# Altium schematic sheet AMX30.SchDoc(AMX30)
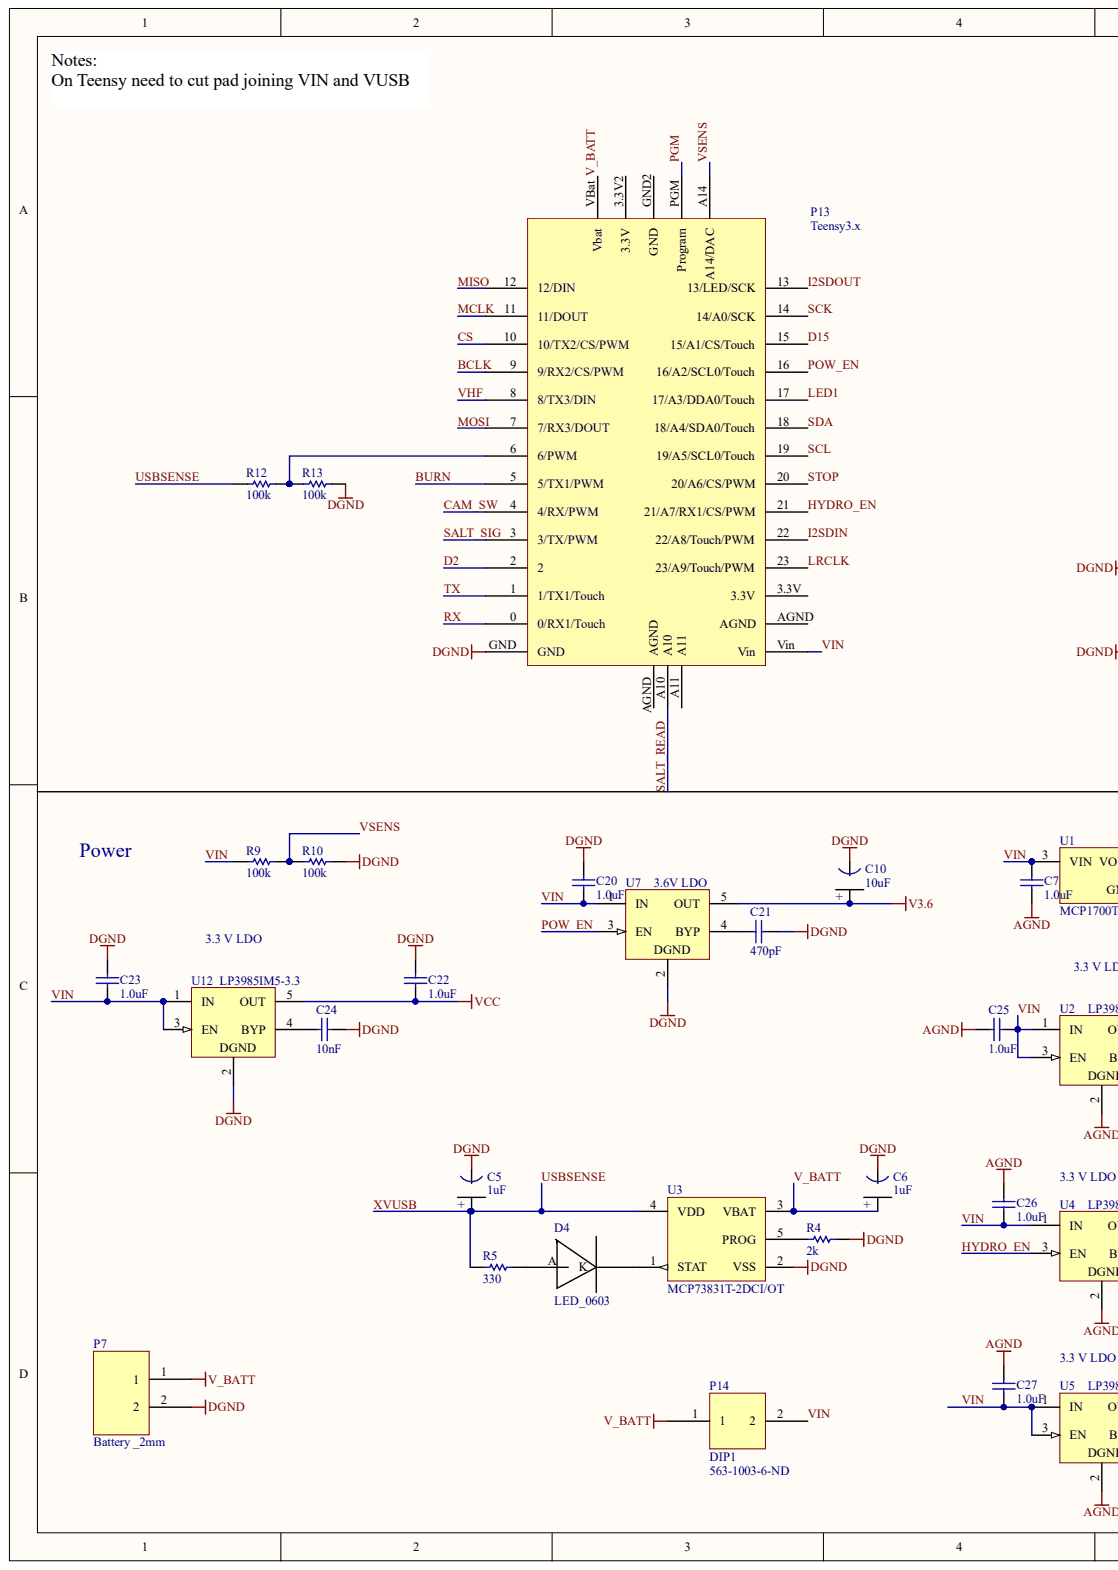
[diagram p0: AMX30.SchDoc, left]
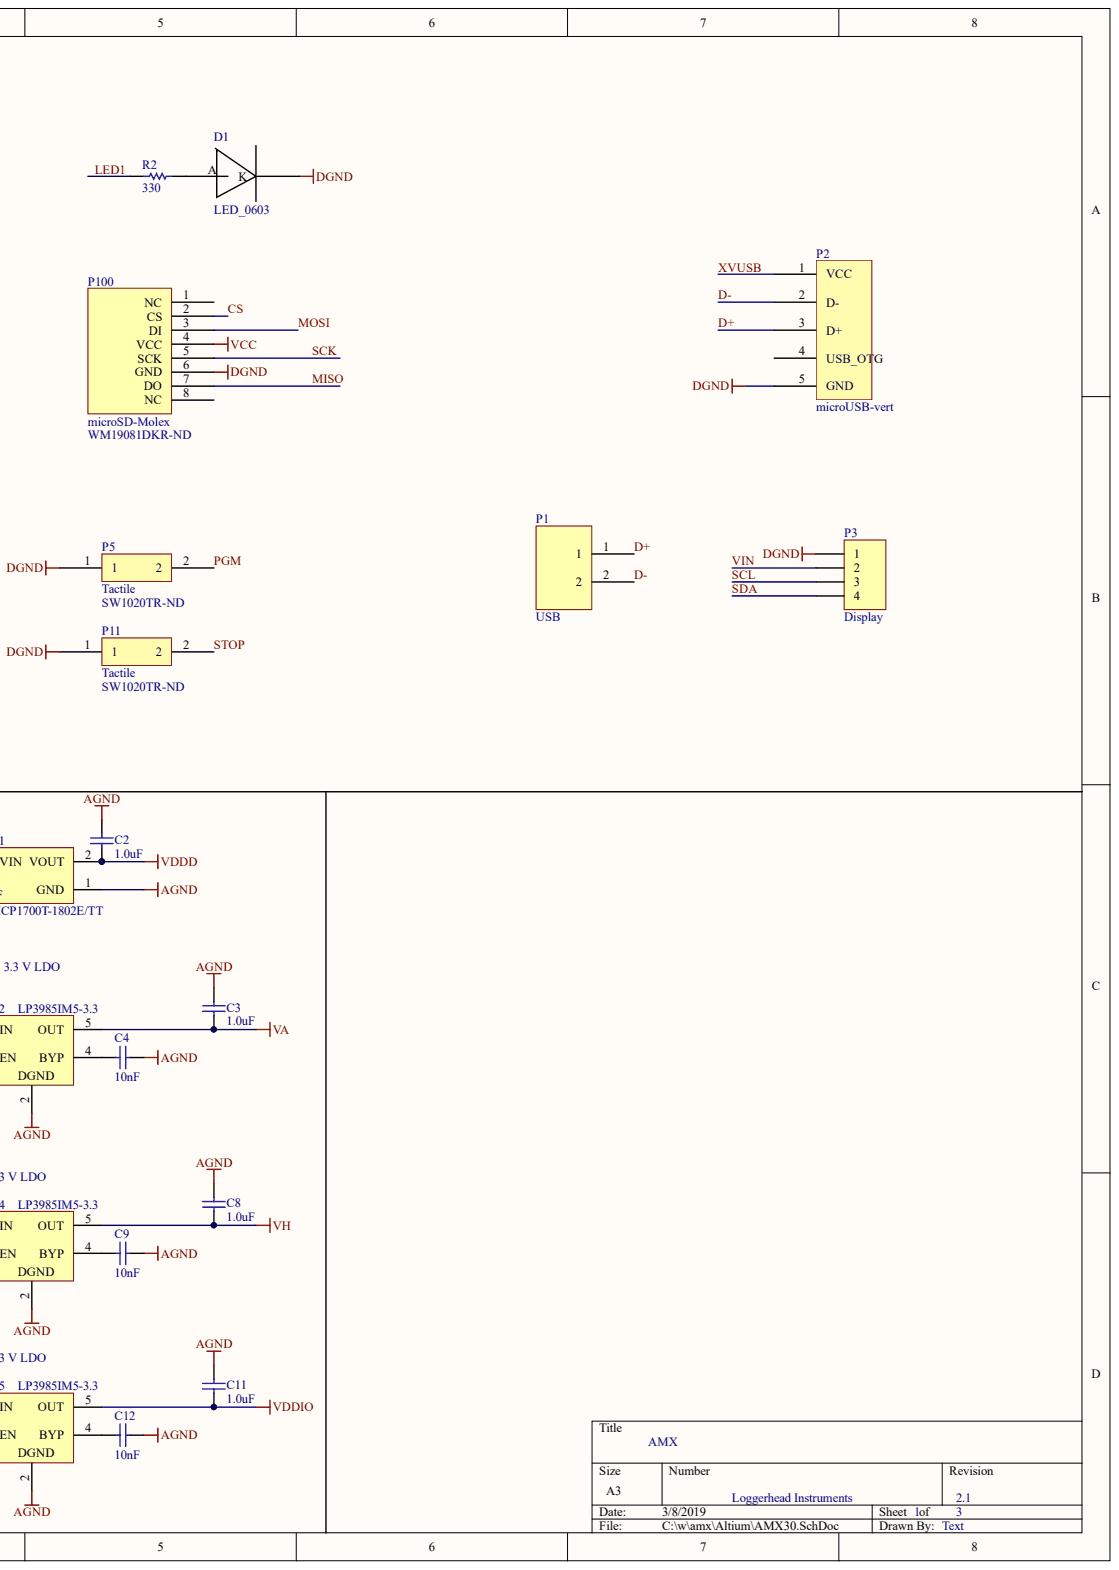
[diagram p1: AMX30.SchDoc, right]

## Parts
C2  1.0uF
C3  1.0uF
C4  10nF
C5  1uF
C6  1uF
C7  1.0uF
C8  1.0uF
C9  10nF
C10  10uF
C11  1.0uF
C12  10nF
C20  1.0uF
C21  470pF
C22  1.0uF
C23  1.0uF
C24  10nF
C25  1.0uF
C26  1.0uF
C27  1.0uF
D1  LED_0603
D4  LED_0603
P1  USB
P2  microUSB-vert
P3  Display
P5  Tactile  Supplier Part Number 1: SW1020TR-ND
P7  Battery _2mm
P11  Tactile  Supplier Part Number 1: SW1020TR-ND
P13  Teensy3.x
P14  DIP1  Supplier Part Number 1: 563-1003-6-ND
P100  microSD-Molex  Supplier Part Number 1: WM19081DKR-ND
R2  330
R4  2k
R5  330
R9  100k
R10  100k
R12  100k
R13  100k
U1  MCP1700T-1802E/TT
U2  LP3985IM5-3.3
U3  MCP73831T-2DCI/OT
U4  LP3985IM5-3.3
U5  LP3985IM5-3.3
U7  3.6V LDO
U12  LP3985IM5-3.3

## Nets
AGND: C2.2 C3.2 C4.1 C7.2 C8.2 C9.1 C11.2 C12.1 C25.2 C26.2 C27.2 U1.1 U2.2 U4.2 U5.2
DGND: C5.1 C6.1 C10.1 C20.2 C21.1 C22.2 C23.2 C24.1 D1.K P2.5(GND) P3.1 P5.1 P7.2 P11.1 P13.GND P100.6(GND) R4.1 R10.1 R13.2 U3.2(VSS) U7.2 U12.2
V3.6: C10.2 U7.5(OUT)
VA: C3.1 U2.5(OUT)
VCC: C22.1 P100.4(VCC) U12.5(OUT)
VDDD: C2.1 U1.2
VDDIO: C11.1 U5.5(OUT)
VH: C8.1 U4.5(OUT)
BCLK: P13.9(9/RX2/CS/PWM)
BURN: P13.5(5/TX1/PWM)
CAM_SW: P13.4(4/RX/PWM)
CS: P13.10(10/TX2/CS/PWM) P100.2(CS)
D2: P13.2
D15: P13.15(15/A1/CS/Touch)
D+: P1.1 P2.3(D+)
D-: P1.2 P2.2(D-)
HYDRO_EN: P13.21(21/A7/RX1/CS/PWM) U4.3(EN)
I2SDIN: P13.22(22/A8/Touch/PWM)
I2SDOUT: P13.13(13/LED/SCK)
LED1: P13.17(17/A3/DDA0/Touch) R2.1
LRCLK: P13.23(23/A9/Touch/PWM)
MCLK: P13.11(11/DOUT)
MISO: P13.12(12/DIN) P100.7(DO)
MOSI: P13.7(7/RX3/DOUT) P100.3(DI)
PGM: P5.2 P13.PGM(Program)
POW_EN: P13.16(16/A2/SCL0/Touch) U7.3(EN)
RX: P13.0(0/RX1/Touch)
SALT_READ: P13.A10
SALT_SIG: P13.3(3/TX/PWM)
SCK: P13.14(14/A0/SCK) P100.5(SCK)
SCL: P3.3 P13.19(19/A5/SCL0/Touch)
SDA: P3.4 P13.18(18/A4/SDA0/Touch)
STOP: P11.2 P13.20(20/A6/CS/PWM)
TX: P13.1(1/TX1/Touch)
USBSENSE: C5.2 P2.1(VCC) R5.1 R12.1 U3.4(VDD)
V_BATT: C6.2 P7.1 P13.VBat(Vbat) P14.1 U3.3(VBAT)
VHF: P13.8(8/TX3/DIN)
VIN: C7.1 C20.1 C23.1 C25.1 C26.1 C27.1 P3.2 P13.Vin P14.2 R9.2 U1.3 U2.1(IN) U2.3(EN) U4.1(IN) U5.1(IN) U5.3(EN) U7.1(IN) U12.1(IN) U12.3(EN)
VSENS: P13.A14(A14/DAC) R9.1 R10.2
NetC4_2: C4.2 U2.4(BYP)
NetC9_2: C9.2 U4.4(BYP)
NetC12_2: C12.2 U5.4(BYP)
NetC21_2: C21.2 U7.4(BYP)
NetC24_2: C24.2 U12.4(BYP)
NetD1_A: D1.A R2.2
NetD4_A: D4.A R5.2
NetD4_K: D4.K U3.1(STAT)
NetP13_6: P13.6(6/PWM) R12.2 R13.1
NetR4_2: R4.2 U3.5(PROG)

Not connected: P2 4; P13 3.3V 3.3V2(3.3V) A11 AGND GND2(GND); P100 1(NC) 8(NC)

## Library data of the parts
C2-C4 C7-C9 C11 C12 C20-C27 = (no symbol name): Note: Footprint corresponds to dimensions for bulk cassette packaging. This case size has tightened tolerances.; Value: 0.1uF; Published: 17-Jul-2002; LatestRevisionDate: 19-Mar-2004; LatestRevisionNote: Silkscreen size reduced.; DatasheetDocument: Mar-2001; PackageDocument: Mar-2001; PackageReference: 0402; Code_JEITA: 0402; Code_IPC: 1005 [0402]; ComponentLink1Description: Manufacturer Link; ComponentLink1URL: http://www.kemet.com/; ComponentLink2Description: Datasheet; ComponentLink2URL: http://www.kemet.com/kemet/web/homepage/kechome.nsf/vapubfilesname/F3102TA.pdf/$file/F3102TA.pdf; Publisher: Altium Limited
C10 = (no symbol name): Value: 1uF
U1 = (no symbol name): Manufacturer: Microchip; DatasheetVersion: revB, Feb-2007; PackageVersion: revBB, Aug-2009; PackageReference: SOT-23-TT3; PackageDescription: 3-Lead Plastic Small Outline Transistor (TT) [SOT-23]; Supplier 1: Digi-Key; Supplier Part Number 1: MCP1700T1802ETTTR-ND; Supplier 2: Digi-Key; Supplier Part Number 2: MCP1700T1802ETTCT-ND; Supplier 3: Digi-Key; Supplier Part Number 3: MCP1700T1802ETTDKR-ND; Supplier 4: Farnell; Supplier Part Number 4: 1296591RL; Supplier 5: Farnell; Supplier Part Number 5: 1296591; Supplier 6: Newark; Supplier Part Number 6: 84R5175; Supplier 7: Mouser; Supplier Part Number 7: 579-MCP1700T1802E/TT; Mounting Technology: Surface Mount; Code_IPC: SOT23-3; PartNumber: MCP1700T-1802E/TT; ComponentLink1Description: Manufacturer URL; ComponentLink1URL: http://www.microchip.com/; ComponentLink2Description: Datasheet; ComponentLink2URL: http://ww1.microchip.com/downloads/en/DeviceDoc/21826b.pdf; ComponentLink3Description: Package Specification; ComponentLink3URL: http://www.microchip.com/stellent/groups/techpub_sg/documents/packagingspec/en012702.pdf
U2 U4 U5 U12 = (no symbol name): Manufacturer: National Semiconductor; CompType: LDO IC; PackageTopMarking: LDQB*XTT; PowerWise: N; Published: 4-Dec-2008; DatasheetVersion: Aug-2005; PackageVersion: revD, Aug-2008; PackageReference: MF05A; PackageDescription: Molded SOT-23, .115 x .063 x .040 in Body, 5 Lead, .0375 in Pitch; PartNumber: LP3985IM5-3.3; RoHS: O; PinCount: 5; DigiKeyPartNumber: LP3985IM5-3.3CT-ND; ComponentLink1Description: LP3985 Datasheet; ComponentLink1URL: http://www.national.com/ds/LP/LP3985.pdf; ComponentLink2Description: LP3985 Web Page; ComponentLink2URL: http://www.national.com/pf/LP/LP3985.html; ComponentLink3Description: MF05A Package Page; ComponentLink3URL: http://www.national.com/packaging/folders/mf05a.html; Publisher: Altium Limited
U7 = (no symbol name): PartNumber: LP3985IM5-3.3; Manufacturer: National Semiconductor; CompType: LDO IC; PackageTopMarking: LDQB*XTT; PowerWise: N; Published: 4-Dec-2008; DatasheetVersion: Aug-2005; PackageVersion: revD, Aug-2008; PackageReference: MF05A; PackageDescription: Molded SOT-23, .115 x .063 x .040 in Body, 5 Lead, .0375 in Pitch; RoHS: O; PinCount: 5; DigiKeyPartNumber: LP3985IM5-3.3CT-ND; ComponentLink1Description: LP3985 Datasheet; ComponentLink1URL: http://www.national.com/ds/LP/LP3985.pdf; ComponentLink2Description: LP3985 Web Page; ComponentLink2URL: http://www.national.com/pf/LP/LP3985.html; ComponentLink3Description: MF05A Package Page; ComponentLink3URL: http://www.national.com/packaging/folders/mf05a.html; Publisher: Altium Limited
C5 C6 D1 D4 P1-P3 P5 P7 P11 P13 P14 P100 R2 R4 R5 R9 R10 R12 R13 U3 = (no symbol name)
  C5 C6: Value: 1uF
  P3: LatestRevisionDate: 17-Jul-2002; LatestRevisionNote: Re-released for DXP Platform.
  P5 P11 P14 P100: Supplier 1: Digi-Key
  R2 R4 R5 R9 R10 R12 R13: Published: 17-Dec-2003; LatestRevisionDate: 19-Mar-2004; LatestRevisionNote: Silkscreen size reduced.; PackageDocument: Mar-2003; PackageReference: 3-0603; Code_IPC: 1608 [0603]; ComponentLink1Description: Manufacturer Link; ComponentLink1URL: http://www.panasonic.com/industrial/components/resistive_products.htm; ComponentLink2Description: Datasheet; ComponentLink2URL: http://www.panasonic.com/industrial/components/pdf/AOA0000CE2.pdf
  U3: DatasheetVersion: revE, Sep-2008; PackageVersion: revBB, Aug-2009; PackageReference: SOT-23-OT5; PackageDescription: 5-Lead Plastic Small Outline Transistor (OT) [SOT-23]; Code_IPC: SOT23-5; ComponentLink1Description: Manufacturer URL; ComponentLink1URL: http://www.microchip.com/; ComponentLink2Description: Datasheet; ComponentLink2URL: http://ww1.microchip.com/downloads/en/DeviceDoc/21984e.pdf; ComponentLink3Description: Package Specification; ComponentLink3URL: http://www.microchip.com/stellent/groups/techpub_sg/documents/packagingspec/en012702.pdf
  P3 R2 R4 R5 R9 R10 R12 R13: Publisher: Altium Limited
